# Altium schematic sheet SS08121_TLCM.SchDoc
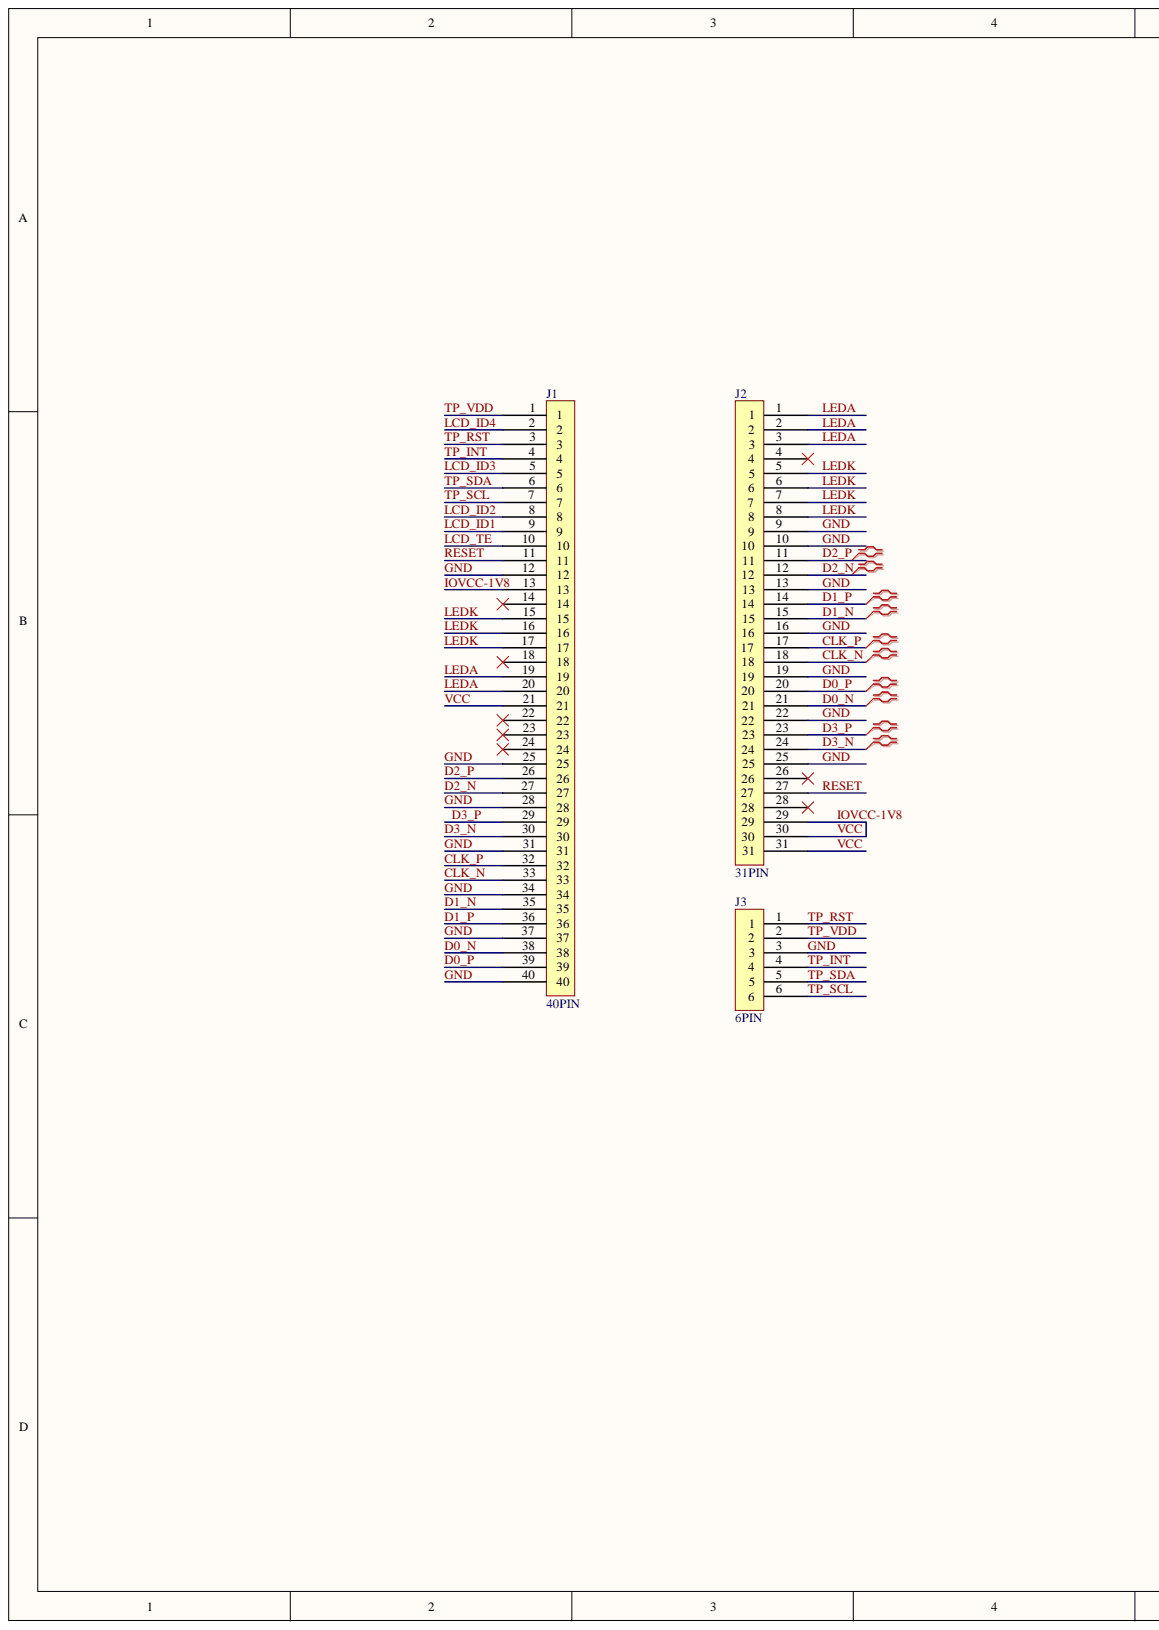
[diagram p0: SS08121_TLCM.SchDoc, left]
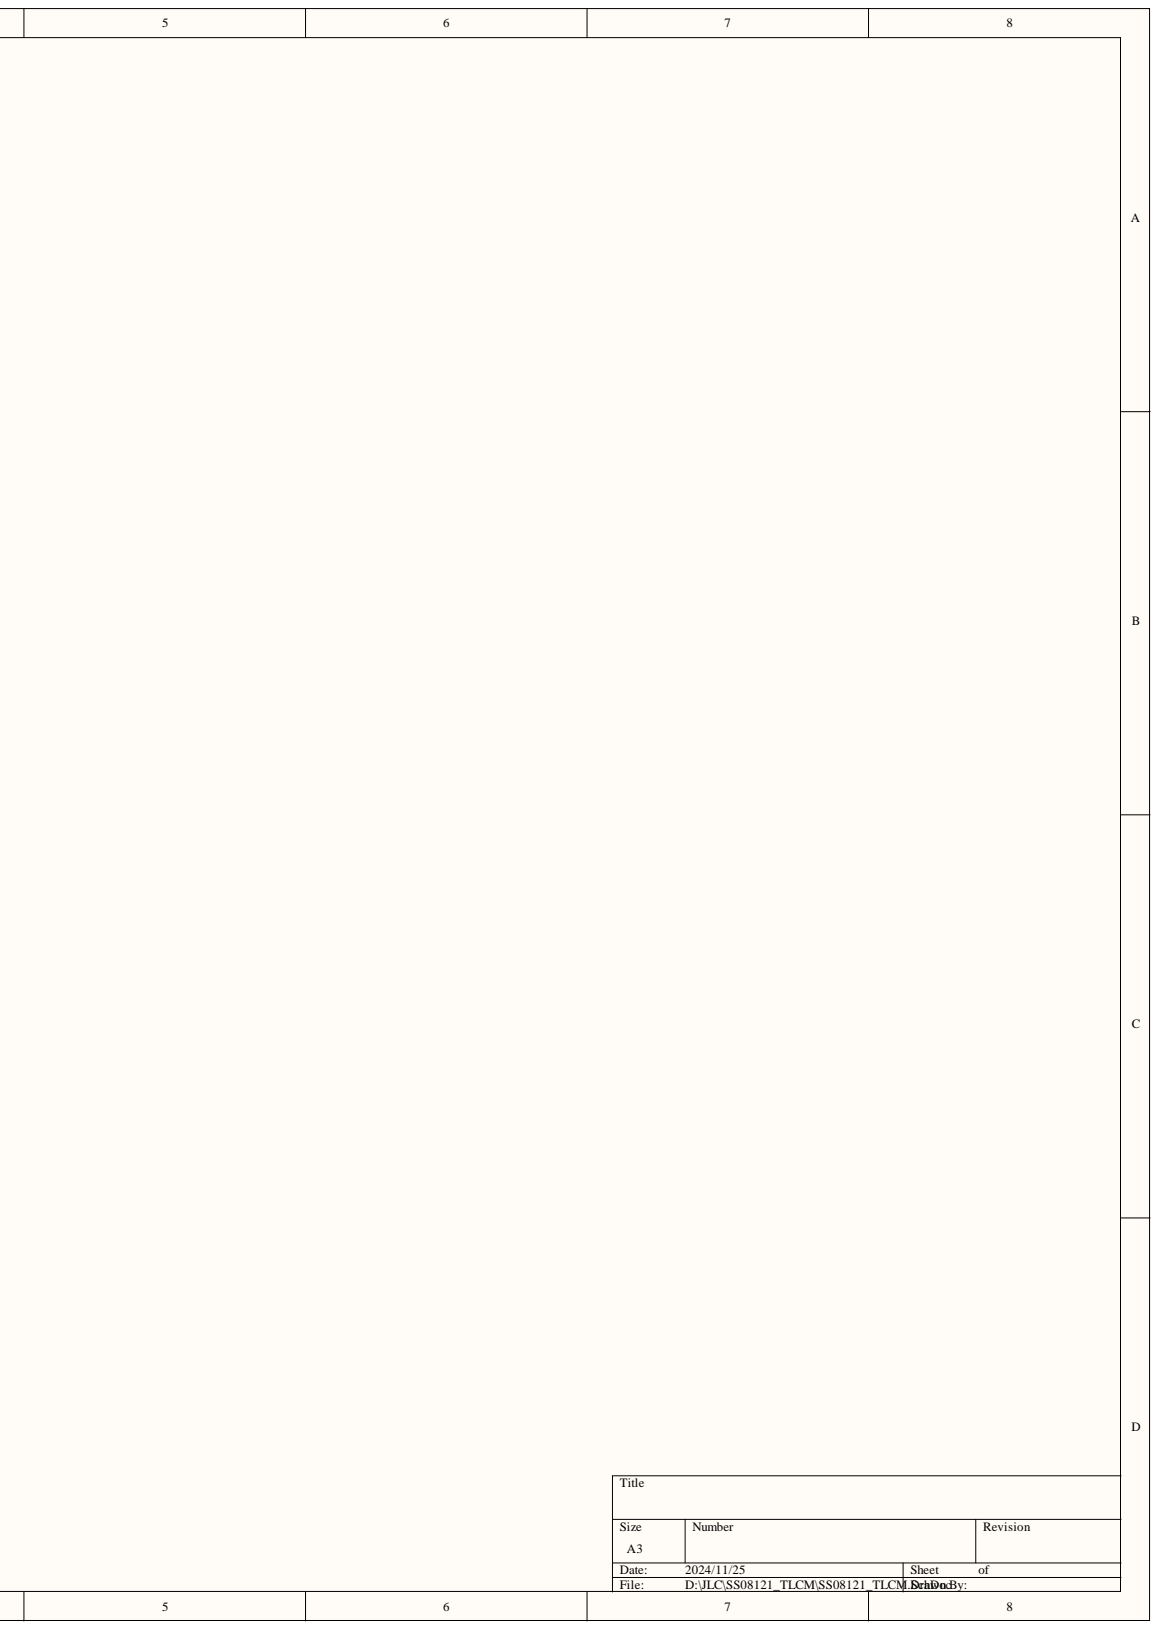
[diagram p1: SS08121_TLCM.SchDoc, right]

## Parts
J1  40PIN  [40P_0.35]
J2  31PIN  [31PIN_FPC_0.3]
J3  6PIN  [6PIN_0.3_0.5_2.85]

## Nets
CLK_N: J1.33 J2.18
CLK_P: J1.32 J2.17
D0_N: J1.38 J2.21
D0_P: J1.39 J2.20
D1_N: J1.35 J2.15
D1_P: J1.36 J2.14
D2_N: J1.27 J2.12
D2_P: J1.26 J2.11
D3_N: J1.30 J2.24
D3_P: J1.29 J2.23
GND: J1.12 J1.25 J1.28 J1.31 J1.34 J1.37 J1.40 J2.9 J2.10 J2.13 J2.16 J2.19 J2.22 J2.25 J3.3
IOVCC-1V8: J1.13 J1.21 J2.29 J2.30 J2.31
LCD_ID1: J1.9
LCD_ID2: J1.8
LCD_ID3: J1.5
LCD_ID4: J1.2
LCD_TE: J1.10
LEDA: J1.19 J1.20 J2.1 J2.2 J2.3
LEDK: J1.15 J1.16 J1.17 J2.5 J2.6 J2.7 J2.8
RESET: J1.11 J2.27
TP_INT: J1.4 J3.4
TP_RST: J1.3 J3.1
TP_SCL: J1.7 J3.6
TP_SDA: J1.6 J3.5
TP_VDD: J1.1 J3.2

Not connected: J1 14 18 22 23 24; J2 4 26 28

## Library data of the parts
J1 = 40PIN: Component Kind: Standard; Library Name: MyLib.SchLib
J2 = 31PIN: Component Kind: Standard; Library Name: MyLib.SchLib
J3 = 6PIN: Component Kind: Standard; Library Name: MyLib.SchLib
